# Altium schematic sheet USB_HOST HUB & USB_OTG.SchDoc
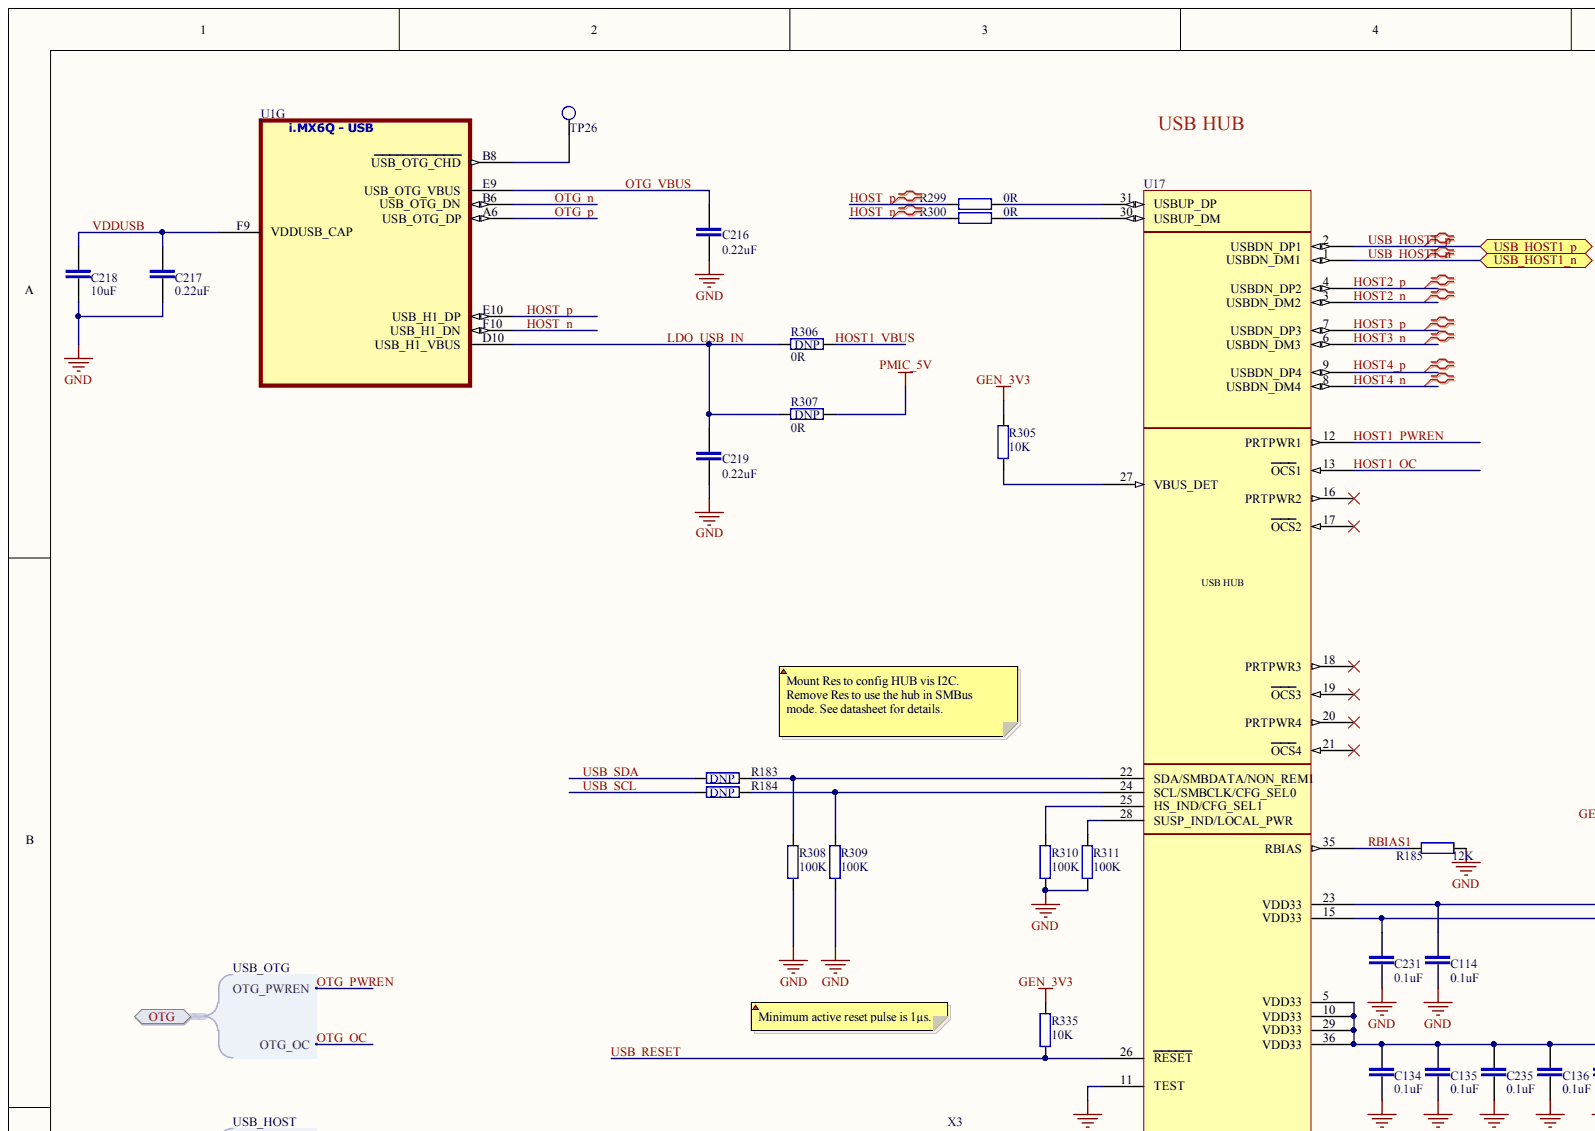
[diagram p0: USB_HOST HUB & USB_OTG.SchDoc, top left]
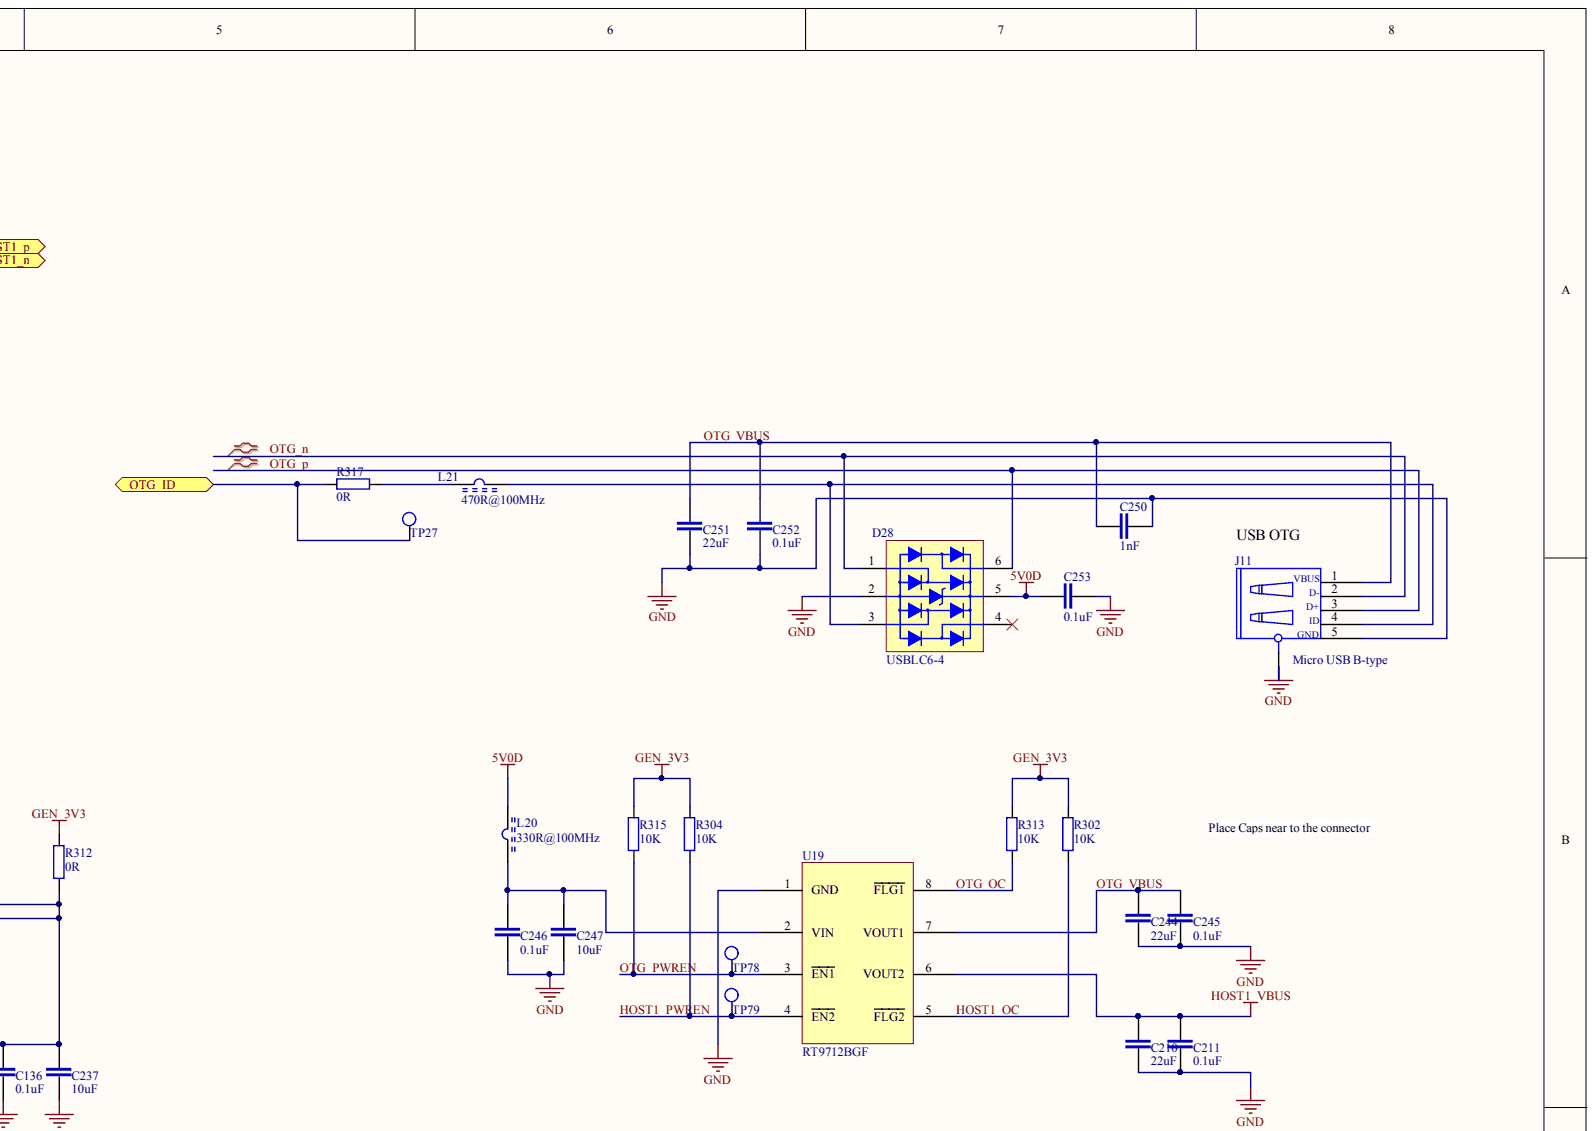
[diagram p1: USB_HOST HUB & USB_OTG.SchDoc, top right]
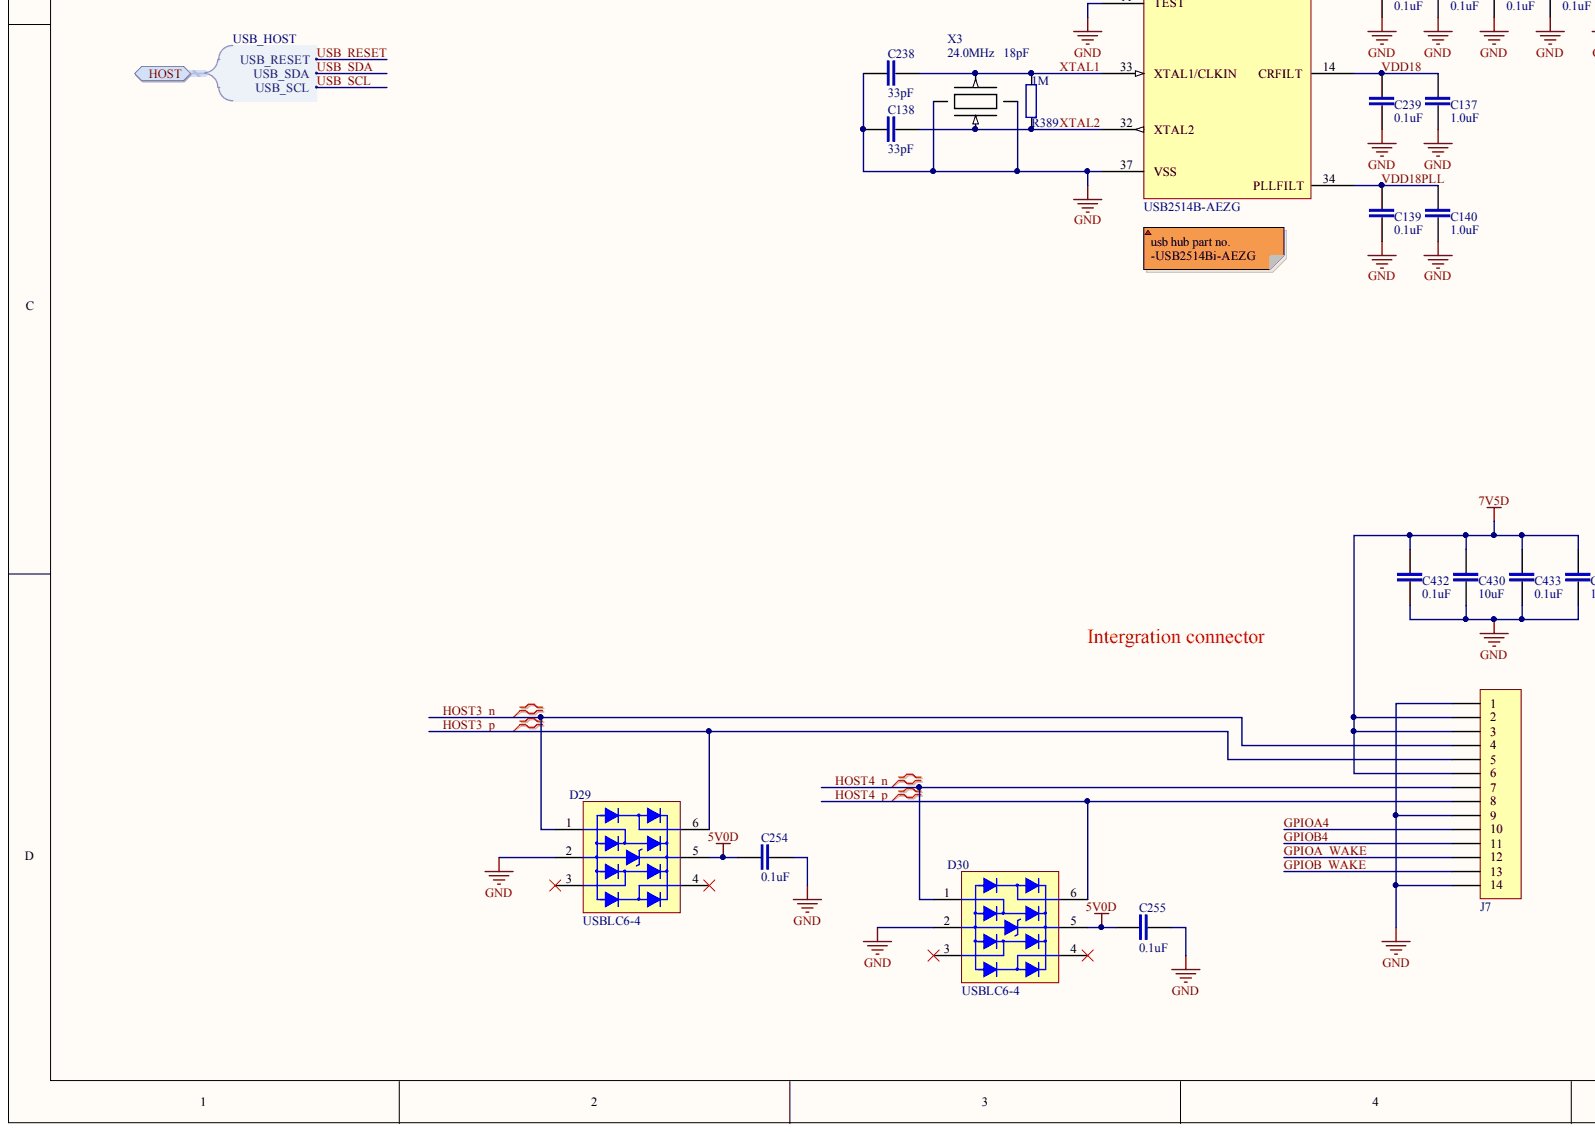
[diagram p2: USB_HOST HUB & USB_OTG.SchDoc, bottom left]
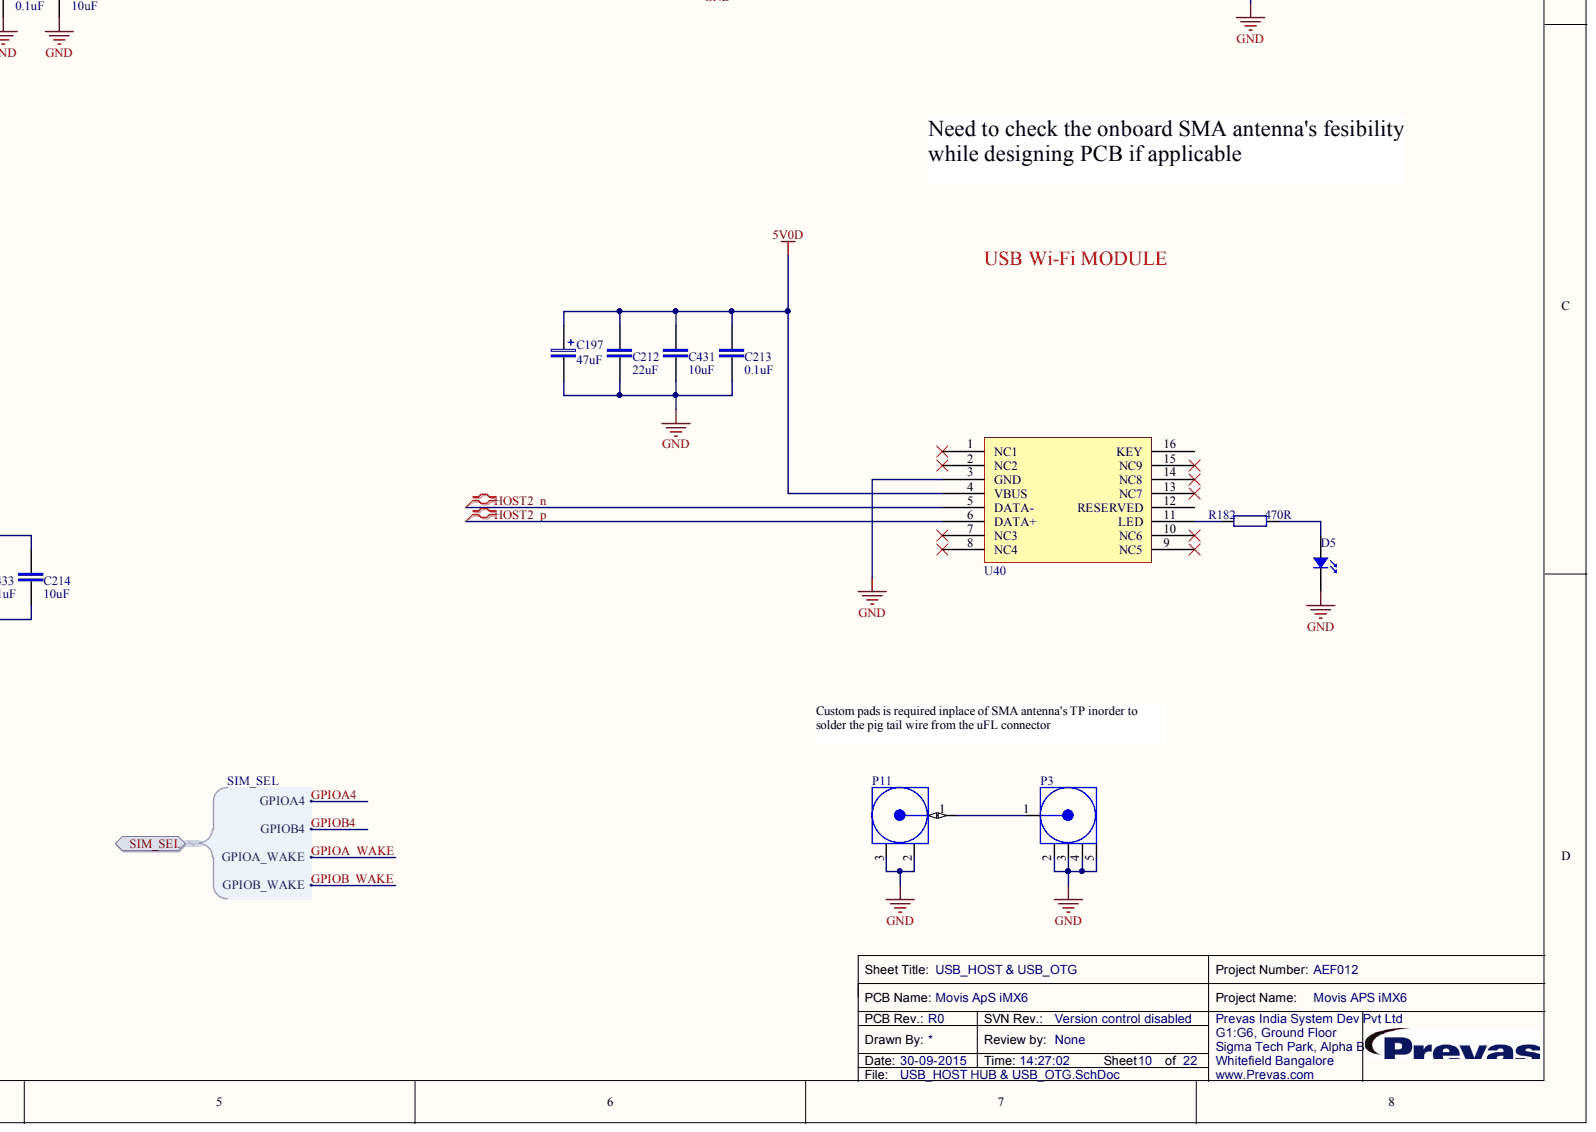
[diagram p3: USB_HOST HUB & USB_OTG.SchDoc, bottom right]

## Parts
C114  0.1uF  [CAPC1005X55N]
C134  0.1uF  [CAPC1005X55N]
C135  0.1uF  [CAPC1005X55N]
C136  0.1uF  [CAPC1005X55N]
C137  1.0uF  [CAPC1608X90N]
C138  33pF  [CAPC1005X55N]
C139  0.1uF  [CAPC1005X55N]
C140  1.0uF  [CAPC1608X90N]
C197  47uF  [CAPMP6032X26N]
C210  22uF  [CAPC3216X180N]
C211  0.1uF  [CAPC1005X55N]
C212  22uF  [CAPC3216X180N]
C213  0.1uF  [CAPC1005X55N]
C214  10uF  [CAPC1608X95N]
C216  0.22uF  [CAPC1005X55N]
C217  0.22uF  [CAPC1005X55N]
C218  10uF  [CAPC1608X95N]
C219  0.22uF  [CAPC1005X55N]
C231  0.1uF  [CAPC1005X55N]
C235  0.1uF  [CAPC1005X55N]
C237  10uF  [CAPC1608X95N]
C238  33pF  [CAPC1005X55N]
C239  0.1uF  [CAPC1005X55N]
C244  22uF  [CAPC3216X180N]
C245  0.1uF  [CAPC1005X55N]
C246  0.1uF  [CAPC1005X55N]
C247  10uF  [CAPC1608X95N]
C250  1nF  [CAPC1005X55N]
C251  22uF  [CAPC3216X180N]
C252  0.1uF  [CAPC1005X55N]
C253  0.1uF  [CAPC1005X55N]
C254  0.1uF  [CAPC1005X55N]
C255  0.1uF  [CAPC1005X55N]
C430  10uF  [CAPC1608X95N]
C431  10uF  [CAPC1608X95N]
C432  0.1uF  [CAPC1005X55N]
C433  0.1uF  [CAPC1005X55N]
D5  APG1608SEKC/T  [Kingbright_APG1608SEKC-T]  (value not drawn)
D28  USBLC6-4  [SOT23-6L]
D29  USBLC6-4  [SOT23-6L]
D30  USBLC6-4  [SOT23-6L]
J7  0533981471  [53398-1471]  (value not drawn)
J11  Micro USB B-type  [FCI_10103594-0001LF]
L20  330R@100MHz  [INDC1608X95N]
L21  470R@100MHz  [INDC4516X180N]
P3  638817-9  [CON_FAKRA_JACK_638817]  (value not drawn)
P11  COAX_2GND  [U.FL-R-SMT-1]  (value not drawn)
R182  470R  [RESC1608X55N]
R183  Fitted: DNP  [RESC1608X55N]  (hidden comment: NM)
R184  Fitted: DNP  [RESC1608X55N]  (hidden comment: NM)
R185  12K  [RESC1608X55N]
R299  0R  [RESC1608X55N]
R300  0R  [RESC1608X55N]
R302  10K  [RESC1608X55N]
R304  10K  [RESC1608X55N]
R305  10K  [RESC1608X55N]
R306  0R  Fitted: DNP  [RESC1608X55N]
R307  0R  Fitted: DNP  [RESC1608X55N]
R308  100K  [RESC1608X55N]
R309  100K  [RESC1608X55N]
R310  100K  [RESC1608X55N]
R311  100K  [RESC1608X55N]
R312  0R  [RESC1608X55N]
R313  10K  [RESC1608X55N]
R315  10K  [RESC1608X55N]
R317  0R  [RESC1608X55N]
R335  10K  [RESC1608X55N]
R389  1M  [RESC1608X55N]
TP26  Testpoint  [TP_SMD]  (value not drawn)  (no BOM)
TP27  Testpoint  [TP_SMD]  (value not drawn)  (no BOM)
TP78  Testpoint  [TP_SMD]  (value not drawn)  (no BOM)
TP79  Testpoint  [TP_SMD]  (value not drawn)  (no BOM)
U1  MCIMX6Q6AVT10AC  [BGA624C80P25X25_2100X2100X160]  (value not drawn)  (part G)
U17  USB2514B-AEZG  [PQFN50P600X600X100-37N]
U19  RT9712BGF  [SOP65P490X110-8N]
U40  WiFiHU-c  [WiFiHU-a-c]  (value not drawn)
X3  24.0MHz  Cload: 18pF  [XTAL700X500X110]

## Ports
HOST, HOST.USB_RESET, HOST.USB_SCL, HOST.USB_SDA, OTG, OTG.OTG_OC, OTG.OTG_PWREN, OTG_ID, SIM_SEL, SIM_SEL.GPIOA4, SIM_SEL.GPIOA_WAKE, SIM_SEL.GPIOB4, SIM_SEL.GPIOB_WAKE, USB_HOST1_N, USB_HOST1_P

## Nets
5V0D: C197.1 C212.2 C213.2 C253.2 C254.2 C255.2 C431.1 D28.5 D29.5 D30.5 L20.2 U40.4(VBUS)
7V5D: C214.1 C430.1 C432.1 C433.1 J7.2 J7.3 J7.6
GEN_3V3: R302.1 R304.1 R305.1 R312.2 R313.1 R315.1 R335.1
GND: C114.1 C134.1 C135.1 C136.1 C137.1 C138.1 C139.1 C140.1 C197.2 C210.1 C211.1 C212.1 C213.1 C214.2 C216.1 C217.1 C218.1 C219.1 C231.1 C235.1 C237.1 C238.1 C239.1 C244.1 C245.1 C246.1 C247.1 C250.2 C251.1 C252.1 C253.1 C254.1 C255.1 C430.2 C431.2 C432.2 C433.2 D5.C D28.2 D29.2 D30.2 J7.1 J7.9 J7.14 J11.5 J11.6 P3.2 P3.3 P3.4 P3.5 P11.2 P11.3 R185.2 R308.1 R309.1 R310.1 R311.1 U17.11(TEST) U17.37(VSS) U19.1(GND) U40.3(GND) X3.2 X3.4
PMIC_5V: R307.1
GPIOA4: J7.10 ; port SIM_SEL
GPIOA_WAKE: J7.12 ; port SIM_SEL
GPIOB4: J7.11 ; port SIM_SEL
GPIOB_WAKE: J7.13 ; port SIM_SEL
HOST1_OC: R302.2 U17.13(OCS1) U19.5(FLG2)
HOST1_PWREN: R304.2 TP79.1 U17.12(PRTPWR1) U19.4(EN2)
HOST1_VBUS: C210.2 C211.2 R306.1 U19.6(VOUT2)
HOST2_N: U17.3(USBDN_DM2) U40.5(DATA-)
HOST2_P: U17.4(USBDN_DP2) U40.6(DATA+)
HOST3_N: D29.1 J7.4 U17.6(USBDN_DM3)
HOST3_P: D29.6 J7.5 U17.7(USBDN_DP3)
HOST4_N: D30.1 J7.7 U17.8(USBDN_DM4)
HOST4_P: D30.6 J7.8 U17.9(USBDN_DP4)
HOST_N: R300.1 U1.F10(USB_H1_DN)
HOST_P: R299.1 U1.E10(USB_H1_DP)
LDO_USB_IN: C219.2 R306.2 R307.2 U1.D10(USB_H1_VBUS)
OTG_ID: R317.2 TP27.1 ; port OTG_ID
OTG_N: D28.1 J11.2(D-) U1.B6(USB_OTG_DN)
OTG_OC: R313.2 U19.8(FLG1) ; port OTG
OTG_P: D28.6 J11.3(D+) U1.A6(USB_OTG_DP)
OTG_PWREN: R315.2 TP78.1 U19.3(EN1) ; port OTG
OTG_VBUS: C216.2 C244.2 C245.2 C250.1 C251.2 C252.2 J11.1(VBUS) U1.E9(USB_OTG_VBUS) U19.7(VOUT1)
RBIAS1: R185.1 U17.35(RBIAS)
USB_HOST1_N: U17.1(USBDN_DM1) ; port USB_HOST1_N
USB_HOST1_P: U17.2(USBDN_DP1) ; port USB_HOST1_P
USB_RESET: R335.2 U17.26(RESET) ; port HOST
USB_SCL: R184.1 ; port HOST
USB_SDA: R183.1 ; port HOST
VDD18: C137.2 C239.2 U17.14(CRFILT)
VDD18PLL: C139.2 C140.2 U17.34(PLLFILT)
VDDUSB: C217.2 C218.2 U1.F9(VDDUSB_CAP)
XTAL1: C238.2 R389.2 U17.33(XTAL1/CLKIN) X3.3
XTAL2: C138.2 R389.1 U17.32(XTAL2) X3.1
NetC114_2: C114.2 C134.2 C135.2 C136.2 C231.2 C235.2 C237.2 R312.1 U17.5(VDD33) U17.10(VDD33) U17.15(VDD33) U17.23(VDD33) U17.29(VDD33) U17.36(VDD33)
NetC246_2: C246.2 C247.2 L20.1 U19.2(VIN)
NetD5_A: D5.A R182.2
NetD28_3: D28.3 J11.4(ID) L21.2
NetL21_1: L21.1 R317.1
NetP3_1: P3.1 P11.1
NetR182_1: R182.1 U40.11(LED)
NetR183_2: R183.2 R308.2 U17.22(SDA/SMBDATA/NON_REM1)
NetR184_2: R184.2 R309.2 U17.24(SCL/SMBCLK/CFG_SEL0)
NetR299_2: R299.2 U17.31(USBUP_DP)
NetR300_2: R300.2 U17.30(USBUP_DM)
NetR305_2: R305.2 U17.27(VBUS_DET)
NetR310_2: R310.2 U17.25(HS_IND/CFG_SEL1)
NetR311_2: R311.2 U17.28(SUSP_IND/LOCAL_PWR)
NetTP26_1: TP26.1 U1.B8(USB_OTG_CHD)

Not connected: D28 4; D29 3 4; D30 3 4; U17 16(PRTPWR2) 17(OCS2) 18(PRTPWR3) 19(OCS3) 20(PRTPWR4) 21(OCS4); U40 1(NC1) 2(NC2) 7(NC3) 8(NC4) 9(NC5) 10(NC6) 12(RESERVED) 13(NC7) 14(NC8) 15(NC9) 16(KEY)

## Library data of the parts
C114 C134-C136 C139 C211 C213 C231 C235 C239 C245 C246 C252-C255 C432 C433 = INNISI-73: CAP CER 0.1UF 50V 10% X5R 0402; ref_part_type: /capacitor/non_polarized/ceramic/; source_manufacturer_1: TDK Corporation; source_manufacturer_1_pn: C1005X5R1H104K050BB; cae_altium_pcb_status: Prototype; Database Table Name: altium_default; db_rev: 7569; elec_temp_range: -55 to +85 C; phy_height: 0.55mm; phy_mounting: SMD; phy_packaging_type: 0402; ref_datasheet: INNISI-71; cae_altium_sch_status: Prototype; Component Kind: Standard; ComponentLink1Description: Datasheet; ComponentLink1URL: https://cop201.prevas.dk/viewvc/datasheet/INNISI-71.pdf?root=hw_components&view=co; db_created: 2015-08-03 03:34:59.0; db_last_updated: 03-08-2015 03:35:01; db_local_id: INNISI-73; db_status: Prototype; elec_tolerance: ±10%; elec_value: 0.1uF; elec_voltage_rating: 50V; phy_material: X5R; ref_keyword: CAP CER 0.1UF 50V 10% X5R 0402; Library Name: altium_mysql.dblib
  C114 C134-C136 C139 C211 C213 C231 C235 C239 C245 C252-C255: Mounted: Yes
C137 C140 = INNISI-79: CAP CER 1UF 16V 10% X7R 0603; ref_part_type: /capacitor/non_polarized/ceramic/; source_manufacturer_1: Murata; source_manufacturer_1_pn: GRM188R71C105KE15D; cae_altium_pcb_status: Prototype; Database Table Name: altium_default; db_rev: 7576; elec_temp_range: -55 to +125; phy_height: 0.90mm; phy_mounting: SMD; phy_packaging_type: 0603 (1608 Metric); ref_datasheet: INNISI-33; ref_keyword: Ceramic Capacitor 0603; cae_altium_sch_status: Prototype; Component Kind: Standard; ComponentLink1Description: Datasheet; ComponentLink1URL: https://cop201.prevas.dk/viewvc/datasheet/INNISI-33.pdf?root=hw_components&view=co; db_created: 2015-08-03 05:39:06.0; db_last_updated: 03-08-2015 05:39:08; db_local_id: INNISI-79; db_status: Prototype; elec_tolerance: 10%; elec_value: 1.0uF; elec_voltage_rating: 16V; Mounted: Yes; phy_material: X7R; Library Name: altium_mysql.dblib
C138 C238 = innisi-80: CAP CER 33PF 50V 5% NPO 0402; ref_part_type: /capacitor/non_polarized/ceramic/; source_manufacturer_1: Yageo; source_manufacturer_1_pn: CC0402JRNPO9BN330; cae_altium_pcb_status: Prototype; Database Table Name: altium_default; db_rev: 7577; elec_temp_range: -55 to +125C; phy_height: 0.55mm; phy_material: C0G,NPO; phy_mounting: SMD; phy_packaging_type: 0402; ref_datasheet: INNISI-72; ref_keyword: Multilayer chip capacitor; cae_altium_sch_status: Prototype; Component Kind: Standard; ComponentLink1Description: Datasheet; ComponentLink1URL: https://cop201.prevas.dk/viewvc/datasheet/INNISI-72.pdf?root=hw_components&view=co; db_created: 2015-08-03 05:45:15.0; db_last_updated: 03-08-2015 05:45:17; db_local_id: INNISI-80; db_status: Prototype; elec_tolerance: 5%; elec_value: 33pF; elec_voltage_rating: 50V; Mounted: Yes; Library Name: altium_mysql.dblib
C197 = INVIHA-51: CAP TANT 47UF 10V 10% 2312; ref_part_type: /capacitor/polarized/ta/; source_manufacturer_1: AVX Corporation; source_manufacturer_1_pn: TAJC476K010RNJ; cae_altium_pcb_status: Prototype; Database Table Name: altium_default; db_rev: 7666; elec_temp_range: -55 to 125°C; phy_height: 2.8mm; phy_material: Tantalum; phy_mounting: SMD; phy_packaging_type: 2312; ref_datasheet: INVIHA-12; ref_keyword: CAPACITOR TANT; cae_altium_sch_status: Prototype; Component Kind: Standard; ComponentLink1Description: Datasheet; ComponentLink1URL: https://cop201.prevas.dk/viewvc/datasheet/INVIHA-12.pdf?root=hw_components&view=co; db_created: 2015-08-05 05:24:04.0; db_last_updated: 05-08-2015 05:24:06; db_local_id: INVIHA-51; db_status: Prototype; elec_tolerance: 10%; elec_value: 47uF; elec_voltage_rating: 10V; Library Name: altium_mysql.dblib
C210 C212 C244 C251 = INNISI-77: CAP CER 22UF 25V 10% X5R 1206; ref_part_type: /capacitor/non_polarized/ceramic/; source_manufacturer_1: Murata Electronics; source_manufacturer_1_pn: GRM31CR61E226KE15L; cae_altium_pcb_status: Prototype; Database Table Name: altium_default; db_rev: 7573; elec_temp_range: -55 to +85 C; phy_height: 1.8mm; phy_mounting: SMD; phy_packaging_type: 1206; ref_datasheet: INNISI-74; ref_keyword: Multilayer chip capacitor; cae_altium_sch_status: Prototype; Component Kind: Standard; ComponentLink1Description: Datasheet; ComponentLink1URL: https://cop201.prevas.dk/viewvc/datasheet/INNISI-74.pdf?root=hw_components&view=co; db_created: 2015-08-03 04:56:21.0; db_last_updated: 03-08-2015 04:56:22; db_local_id: INNISI-77; db_status: Prototype; elec_tolerance: 10%; elec_value: 22uF; elec_voltage_rating: 25V; phy_material: X5R; Library Name: altium_mysql.dblib
C214 C237 C430 C431 = INNISI-78: CAP CER 10UF 16V 10% X5R 0603; ref_part_type: /capacitor/non_polarized/ceramic/; source_manufacturer_1: Murata; source_manufacturer_1_pn: GRM188R61C106KAALD; cae_altium_pcb_status: Prototype; Database Table Name: altium_default; db_rev: 7574; elec_temp_range: -55 to +85C; phy_height: 0.95mm; phy_mounting: SMD; phy_packaging_type: 0603; ref_datasheet: INNISI-75; ref_keyword: Ceramic capacitor X5R 0603; cae_altium_sch_status: Prototype; Component Kind: Standard; ComponentLink1Description: Datasheet; ComponentLink1URL: https://cop201.prevas.dk/viewvc/datasheet/INNISI-75.pdf?root=hw_components&view=co; db_created: 2015-08-03 05:08:42.0; db_last_updated: 03-08-2015 05:08:44; db_local_id: INNISI-78; db_status: Prototype; elec_tolerance: 10%; elec_value: 10uF; elec_voltage_rating: 16V; phy_material: X5R; Library Name: altium_mysql.dblib
  C237: Mounted: Yes
C216 C217 C219 = innisi-76: CAP CER 0.22UF 16V 10% X7R 0402; ref_part_type: /capacitor/non_polarized/ceramic/; source_manufacturer_1: Murata; source_manufacturer_1_pn: GRM155R71C224KA12D; cae_altium_pcb_status: Prototype; Database Table Name: altium_default; db_rev: 7572; elec_temp_range: -55 to +125C; phy_height: 0.55mm; phy_mounting: SMD; phy_packaging_type: 0402; ref_datasheet: INNISI-73; ref_keyword: Ceramic capacitor X7R 0402; cae_altium_sch_status: Prototype; Component Kind: Standard; ComponentLink1Description: Datasheet; ComponentLink1URL: https://cop201.prevas.dk/viewvc/datasheet/INNISI-73.pdf?root=hw_components&view=co; db_created: 2015-08-03 04:28:56.0; db_last_updated: 03-08-2015 04:28:58; db_local_id: INNISI-76; db_status: Prototype; elec_tolerance: 10%; elec_value: 0.22uF; elec_voltage_rating: 16V; phy_material: X7R; Library Name: altium_mysql.dblib
C218 C247 = innisi-78: CAP CER 10UF 16V 10% X5R 0603; ref_part_type: /capacitor/non_polarized/ceramic/; source_manufacturer_1: Murata; source_manufacturer_1_pn: GRM188R61C106KAALD; cae_altium_pcb_status: Prototype; Database Table Name: altium_default; db_rev: 7574; elec_temp_range: -55 to +85C; phy_height: 0.95mm; phy_mounting: SMD; phy_packaging_type: 0603; ref_datasheet: INNISI-75; ref_keyword: Ceramic capacitor X5R 0603; cae_altium_sch_status: Prototype; Component Kind: Standard; ComponentLink1Description: Datasheet; ComponentLink1URL: https://cop201.prevas.dk/viewvc/datasheet/INNISI-75.pdf?root=hw_components&view=co; db_created: 2015-08-03 05:08:42.0; db_last_updated: 03-08-2015 05:08:44; db_local_id: INNISI-78; db_status: Prototype; elec_tolerance: 10%; elec_value: 10uF; elec_voltage_rating: 16V; phy_material: X5R; Library Name: altium_mysql.dblib
C250 = INNISI-84: CAP CER 1nF 50V 10% X7R 0402; ref_part_type: /capacitor/non_polarized/ceramic/; source_manufacturer_1: Murata; source_manufacturer_1_pn: GRM155R71H102KA01J; cae_altium_pcb_status: Prototype; Database Table Name: altium_default; db_rev: 7585; elec_temp_range: -55 to +125C; phy_height: 0.55mm; phy_mounting: SMD; phy_packaging_type: 0402; ref_datasheet: INNISI-73; ref_keyword: Ceramic capacitor X7R 0402; cae_altium_sch_status: Prototype; Component Kind: Standard; ComponentLink1Description: Datasheet; ComponentLink1URL: https://cop201.prevas.dk/viewvc/datasheet/INNISI-73.pdf?root=hw_components&view=co; db_created: 2015-08-03 06:26:19.0; db_last_updated: 03-08-2015 06:26:21; db_local_id: INNISI-84; db_status: Prototype; elec_tolerance: 10%; elec_value: 1nF; elec_voltage_rating: 50V; Mounted: Yes; phy_material: X7R; Library Name: altium_mysql.dblib
D5 = GEPW-159: SMD LED, 610nm, 300mcd; ref_part_type: /optical/emmision/diode/; source_manufacturer_1: Kingbright; cae_altium_pcb_status: Prototype; Database Table Name: altium_default; db_rev: 3356; elec_current_rating: IF=20mA; elec_temp_range: -40 to 85; elec_voltage_rating: 2.05V; phy_height: 0.25; phy_packaging_type: 0603; ref_datasheet: GEPW-137; ref_keyword: Super Bright Orange; cae_altium_sch_status: Prototype; Component Kind: Standard; ComponentLink1Description: Datasheet; ComponentLink1URL: https://cop201.prevas.dk/viewvc/datasheet/GEPW-137.pdf?root=hw_components&view=co; db_created: 2011-04-26 11:07:13.0; db_last_updated: 26-04-2011 11:07:13; db_local_id: GEPW-159; db_status: Prototype; elec_frequency_rating: 610nm; elec_value: APG1608SEKC/T; phy_mounting: SMD; source_manufacturer_1_pn: APG1608SEKC/T; Library Name: altium_mysql.dblib
D28-D30 = NIMA-155: Dual very low capacitance ESD protection for USB 2.0 up to 480Mb/s; ref_part_type: /diode/transient/; source_manufacturer_1: STMicroelectronics; cae_altium_pcb_status: Prototype; Database Table Name: altium_default; db_rev: 1817; elec_temp_range: -40 to 125; elec_voltage_rating: 5V; phy_height: 1.45mm; phy_mounting: SMD; ref_datasheet: NIMA-115; cae_altium_sch_status: Prototype; Component Kind: Standard; ComponentLink1Description: Datasheet; ComponentLink1URL: https://cop201.prevas.dk/viewvc/datasheet/NIMA-115.pdf?root=hw_components&view=co; db_created: 2009-09-25 21:20:59; db_last_updated: 25-09-2009 21:21:20; db_local_id: NIMA-155; db_status: Prototype; elec_value: USBLC6-4; Mounted: Yes; phy_packaging_type: SOT23-6L; ref_keyword: ESD; source_manufacturer_1_pn: USBLC6-4; Library Name: altium_mysql.dblib
J7 = INNISI-67: CONN HEADER 14POS 1.25MM VRT SMD; ref_part_type: /connector/14 pins/; source_manufacturer_1: Molex Inc; cae_altium_pcb_status: Prototype; Database Table Name: altium_default; db_rev: 7500; elec_current_rating: 1 A; ref_datasheet: INNISI-66; ref_keyword: PicoBlade Header; cae_altium_sch_status: Prototype; Component Kind: Standard; ComponentLink1Description: Datasheet; ComponentLink1URL: https://cop201.prevas.dk/viewvc/datasheet/INNISI-66.pdf?root=hw_components&view=co; db_created: 2015-07-06 03:02:08.0; db_last_updated: 06-07-2015 03:02:10; db_local_id: INNISI-67; db_status: Prototype; elec_value: 0533981471; phy_mounting: SMD; source_manufacturer_1_pn: 0533981471; Library Name: altium_mysql.dblib
J11 = CHPE-234: MICRO USB B, RECEPTACLE, 5POS, SURFACE MOUNT RIGHT ANGLE; ref_part_type: /connector/; source_manufacturer_1: FCI; source_manufacturer_1_pn: 10103594-0001LF; cae_altium_pcb_status: Prototype; Database Table Name: altium_default; db_rev: 4334; phy_height: 2.99; phy_mounting: SMD; ref_datasheet: GEPW-195; ref_keyword: USB Micro Bconnector; cae_altium_sch_status: Prototype; Component Kind: Standard; ComponentLink1Description: Datasheet; ComponentLink1URL: https://cop201.prevas.dk/viewvc/datasheet/GEPW-195.pdf?root=hw_components&view=co; db_created: 2012-02-22 13:05:39.0; db_last_updated: 22-02-2012 14:46:37; db_local_id: CHPE-234; db_status: Prototype; elec_value: Micro USB B-type; Library Name: altium_mysql.dblib
L20 = TELA-164: Chip EMIFIL Inductor Type, 330R@100MHz, 0.15R@0Hz; ref_part_type: /inductor/lossy/; source_manufacturer_1: Murata; source_manufacturer_1_pn: BLM18PG331SN1*; cae_altium_pcb_status: Prototype; Database Table Name: altium_default; db_rev: 5543; elec_current_rating: 1.2A; elec_frequency_rating: 100MHz; elec_temp_range: -55 to 125; elec_tolerance: 25%; phy_height: 0.95mm; phy_material: Ferrite; phy_mounting: SMD; phy_packaging_type: 0603; ref_datasheet: SOMO-49; ref_keyword: Ferrite bead 0603; cae_altium_sch_status: Prototype; Component Kind: Standard; ComponentLink1Description: Datasheet; ComponentLink1URL: https://cop201.prevas.dk/viewvc/datasheet/SOMO-49.pdf?root=hw_components&view=co; db_created: 2013-03-14 15:35:31.0; db_last_updated: 14-03-2013 16:11:58; db_local_id: TELA-164; db_status: Prototype; elec_value: 330R@100MHz; Library Name: altium_mysql.dblib
L21 = NIMA-36: Chip EMIFIL Inductor Type, 470R@100MHz, 0.05R@0Hz; ref_part_type: /inductor/lossy/; source_manufacturer_1: Murata; source_manufacturer_1_pn: BLM41PG471SN1L; cae_altium_pcb_status: Prototype; Database Table Name: altium_default; db_rev: 5393; elec_current_rating: 2.0A; elec_frequency_rating: 100MHz; elec_temp_range: -55 to 125; elec_tolerance: 25%; phy_height: 1.8mm; phy_material: Ferrite; phy_mounting: SMD; phy_packaging_type: 1806; ref_datasheet: NIMA-29; ref_keyword: Ferrite bead 1806; cae_altium_sch_status: Prototype; Component Kind: Standard; ComponentLink1Description: Datasheet; ComponentLink1URL: https://cop201.prevas.dk/viewvc/datasheet/NIMA-29.pdf?root=hw_components&view=co; db_created: 2009-03-20 21:04:27; db_last_updated: 05-12-2012 15:13:29; db_local_id: NIMA-36; db_status: Prototype; elec_value: 470R@100MHz; Library Name: altium_mysql.dblib
P3 = INNISI-61: D/C R/A PCB FAKRA SMB ASY,KEYI; ref_part_type: /connector/; source_manufacturer_1: TE Connectivity; cae_altium_pcb_status: Prototype; Database Table Name: altium_default; db_rev: 7952; elec_current_rating: 1A; elec_frequency_rating: 0 – 3000MHz; elec_temp_range: -40°C to + 85?°C; phy_height: 10.75?mm; phy_mounting: Leaded; ref_datasheet: INNISI-59; ref_keyword: RF Connectors; cae_altium_sch_status: Prototype; Component Kind: Standard; ComponentLink1Description: Datasheet; ComponentLink1URL: https://cop201.prevas.dk/viewvc/datasheet/INNISI-59.pdf?root=hw_components&view=co; db_created: 2015-06-17 07:10:25.0; db_last_updated: 25-09-2015 05:38:56; db_local_id: INNISI-61; db_status: Prototype; elec_value: 638817-9; source_manufacturer_1_pn: 638817-9; Library Name: altium_mysql.dblib
P11 = INNISI-66: Ultra Small Surface Mount Coaxial Connectors; ref_part_type: /connector/; source_manufacturer_1: Hirose Electric; source_manufacturer_1_pn: U.FL-R-SMT-1(01); cae_altium_pcb_status: Prototype; Database Table Name: altium_default; db_rev: 7499; elec_current_rating: 10mA; elec_temp_range: -40C - +90C; phy_mounting: SMD; ref_datasheet: INNISI-65; ref_keyword: U.FL connectors; cae_altium_sch_status: Prototype; Component Kind: Standard; ComponentLink1Description: Datasheet; ComponentLink1URL: https://cop201.prevas.dk/viewvc/datasheet/INNISI-65.pdf?root=hw_components&view=co; db_created: 2015-07-06 02:54:10.0; db_last_updated: 06-07-2015 02:54:11; db_local_id: INNISI-66; db_status: Prototype; Library Name: altium_mysql.dblib
R182 = INVIHA-7: RES SMD 470 OHM 1% 1/10W 0603; ref_part_type: /resistor/fixed/; source_manufacturer_1: Yageo; source_manufacturer_1_pn: RC0603FR-07470RL; cae_altium_pcb_status: Prototype; Database Table Name: altium_default; db_rev: 7584; elec_power_rating: 0.1W; elec_temp_range: -55 to +155° C; phy_height: 0.55mm; phy_packaging_type: 0603; ref_datasheet: INVIHA-2; ref_keyword: Chip Resistor; cae_altium_sch_status: Prototype; Component Kind: Standard; ComponentLink1Description: Datasheet; ComponentLink1URL: https://cop201.prevas.dk/viewvc/datasheet/INVIHA-2.pdf?root=hw_components&view=co; db_created: 2015-08-03 06:24:05.0; db_last_updated: 03-08-2015 6:24:06 AM; db_local_id: INVIHA-7; db_status: Prototype; elec_tolerance: 1%; elec_value: 470R; phy_mounting: SMD; Library Name: altium_mysql.dblib
R183 R184 = CHPE-128: Resistor 0603 Not Mounted; ref_part_type: /resistor/fixed/; cae_altium_pcb_status: Prototype; Database Table Name: altium_default; db_rev: 3505; phy_height: 0.55mm; phy_material: Thick Film; phy_mounting: SMD; phy_packaging_type: 0603; ref_keyword: Thick Film Chip Resistor; cae_altium_sch_status: Prototype; Component Kind: Standard; ComponentLink1Description: Datasheet; db_created: 2011-05-25 12:57:29.0; db_last_updated: 25-05-2011 12:57:29; db_local_id: CHPE-128; db_status: Prototype; elec_value: NM; Library Name: altium_mysql.dblib
R185 = INVIHA-17: RES SMD 12K OHM 1% 1/10W 0603; ref_part_type: /resistor/fixed/; source_manufacturer_1: Yageo; source_manufacturer_1_pn: RC0603FR-0712KL; cae_altium_pcb_status: Prototype; Database Table Name: altium_default; db_rev: 7599; elec_power_rating: 0.1W; elec_temp_range: -55 to +155° C; phy_height: 0.55mm; phy_packaging_type: 0603; ref_datasheet: INVIHA-2; ref_keyword: Chip Resistor; cae_altium_sch_status: Prototype; Component Kind: Standard; ComponentLink1Description: Datasheet; ComponentLink1URL: https://cop201.prevas.dk/viewvc/datasheet/INVIHA-2.pdf?root=hw_components&view=co; db_created: 2015-08-03 07:05:19.0; db_last_updated: 03-08-2015 7:05:21 AM; db_local_id: INVIHA-17; db_status: Prototype; elec_tolerance: 1%; elec_value: 12K; phy_mounting: SMD; Library Name: altium_mysql.dblib
R299 R300 R306 R307 R312 R317 = INVIHA-4: RES SMD 0.0 OHM JUMPER 1/10W; ref_part_type: /resistor/fixed/; source_manufacturer_1: Panasonic Electronic Components; source_manufacturer_1_pn: ERJ-3GEY0R00V; cae_altium_pcb_status: Prototype; Database Table Name: altium_default; db_rev: 7580; elec_power_rating: 0.1W; elec_temp_range: -55 to +155° C; phy_height: 0.55mm; phy_packaging_type: 0603; ref_datasheet: INVIHA-3; ref_keyword: Chip Resistor; cae_altium_sch_status: Prototype; Component Kind: Standard; ComponentLink1Description: Datasheet; ComponentLink1URL: https://cop201.prevas.dk/viewvc/datasheet/INVIHA-3.pdf?root=hw_components&view=co; db_created: 2015-08-03 05:59:19.0; db_last_updated: 03-08-2015 5:59:21 AM; db_local_id: INVIHA-4; db_status: Prototype; elec_value: 0R; phy_mounting: SMD; Library Name: altium_mysql.dblib
R302 R304 R305 R313 R315 R335 = INVIHA-3: RES SMD 10K OHM 1% 1/10W 0603; ref_part_type: /resistor/fixed/; source_manufacturer_1: Yageo; source_manufacturer_1_pn: RC0603FR-0710KL; cae_altium_pcb_status: Prototype; Database Table Name: altium_default; db_rev: 7575; elec_power_rating: 0.1W; elec_temp_range: -55 to +155° C; phy_height: 0.55mm; phy_packaging_type: 0603; ref_datasheet: INVIHA-2; ref_keyword: Chip Resistor; cae_altium_sch_status: Prototype; Component Kind: Standard; ComponentLink1Description: Datasheet; ComponentLink1URL: https://cop201.prevas.dk/viewvc/datasheet/INVIHA-2.pdf?root=hw_components&view=co; db_created: 2015-08-03 05:39:03.0; db_last_updated: 03-08-2015 5:39:05 AM; db_local_id: INVIHA-3; db_status: Prototype; elec_tolerance: 1%; elec_value: 10K; Mounted: Yes; phy_mounting: SMD; Library Name: altium_mysql.dblib
R308-R311 = INVIHA-20: RES SMD 100K OHM 1% 1/10W 0603; ref_part_type: /resistor/fixed/; source_manufacturer_1: Yageo; source_manufacturer_1_pn: RC0603FR-07100KL; cae_altium_pcb_status: Prototype; Database Table Name: altium_default; db_rev: 7602; elec_power_rating: 0.1W; elec_temp_range: -55 to +155° C; phy_height: 0.55mm; phy_packaging_type: 0603; ref_keyword: Chip Resistor; cae_altium_sch_status: Prototype; Component Kind: Standard; ComponentLink1Description: Datasheet; ComponentLink1URL: https://cop201.prevas.dk/viewvc/datasheet/INVIHA-2.pdf?root=hw_components&view=co; db_created: 2015-08-03 07:16:43.0; db_last_updated: 03-08-2015 7:16:45 AM; db_local_id: INVIHA-20; db_status: Prototype; elec_tolerance: 1%; elec_value: 100K; phy_mounting: SMD; ref_datasheet: INVIHA-2; Library Name: altium_mysql.dblib
  R310 R311: Mounted: Yes
R389 = INVIHA-31: RES SMD 1M OHM 1% 1/10W 0603; ref_part_type: /resistor/fixed/; source_manufacturer_1: Yageo; source_manufacturer_1_pn: RC0603FR-071ML; cae_altium_pcb_status: Prototype; Database Table Name: altium_default; db_rev: 7622; elec_power_rating: 0.1W; elec_temp_range: -55 to +155° C; phy_height: 0.55mm; phy_packaging_type: 0603; ref_datasheet: INVIHA-2; ref_keyword: Chip Resistor; cae_altium_sch_status: Prototype; Component Kind: Standard; ComponentLink1Description: Datasheet; ComponentLink1URL: https://cop201.prevas.dk/viewvc/datasheet/INVIHA-2.pdf?root=hw_components&view=co; db_created: 2015-08-03 08:46:27.0; db_last_updated: 03-08-2015 8:46:29 AM; db_local_id: INVIHA-31; db_status: Prototype; elec_tolerance: 1%; elec_value: 1M; phy_mounting: SMD; Library Name: altium_mysql.dblib
TP26 TP27 TP78 TP79 = JEPE-114: Test Point SMD; ref_part_type: /pcb_item/; cae_altium_pcb_status: Prototype; Database Table Name: altium_default; db_rev: 3281; cae_altium_sch_status: Prototype; ComponentLink1Description: Datasheet; db_created: 2008-08-18 11:39:42; db_last_updated: 23-03-2011 14:14:06; db_local_id: JEPE-114; db_status: Prototype; elec_value: Test Point; phy_mounting: SMD; ref_keyword: Test  Point; Library Name: altium_mysql.dblib
U1 = INRAAN-5: i.MX 6Dual/6Quad; ref_part_type: /integrated/digital/processor/general/; AGILE_PN: 344-01218; CAD_APPROVED: Yes; cae_altium_pcb_status: Prototype; CLASS: IC; Database Table Name: altium_default; db_rev: 6247; elec_temp_range: -40 to +105; elec_voltage_rating: 1.5V; MFG_NAME01: FREESCALE SEMICONDUCTOR; phy_height: 1.85; phy_mounting: SMD; phy_packaging_type: FCPBGA96_624; ref_datasheet: INRAAN-6; cae_altium_sch_status: Prototype; Component Kind: Standard; ComponentLink1Description: Datasheet; ComponentLink1URL: https://cop201.prevas.dk/viewvc/datasheet/INRAAN-6.pdf?root=hw_components&view=co; db_created: 2013-10-21 12:25:57.0; db_last_updated: 27-12-2013 09:32:57; db_local_id: INRAAN-5; db_status: Prototype; elec_value: MCIMX6Q6AVT10AC; HEIGHT: 2.16MM; MFG_PN01: MCIMX6Q6AVT10AC; ref_keyword: i.MX 6Dual/6Quad; ROHS: Yes; source_manufacturer_1: Freescale Semiconductor; source_manufacturer_1_pn: MCIMX6Q6AVT10AC; Library Name: altium_mysql.dblib
U17 = CHPE-139: USB 2.0 High-Speed 4-Port Hub Controller; ref_part_type: /integrated/digital/interface/usb/; source_manufacturer_1: SMSC; cae_altium_pcb_status: Prototype; Database Table Name: altium_default; db_rev: 3604; elec_temp_range: 0 to 85; elec_voltage_rating: 3.3V; phy_height: 1.0mm; phy_mounting: SMD; phy_packaging_type: QFN-36; ref_datasheet: TELA-48; ref_keyword: USB Hub 4-Port; cae_altium_sch_status: Prototype; Component Kind: Standard; ComponentLink1Description: Datasheet; ComponentLink1URL: https://cop201.prevas.dk/viewvc/datasheet/TELA-48.pdf?root=hw_components&view=co; db_created: 2011-06-01 16:58:09.0; db_last_updated: 09-06-2011 20:14:12; db_local_id: CHPE-139; db_status: Prototype; elec_value: USB2514B-AEZG; source_manufacturer_1_pn: USB2514B-AEZG; Library Name: altium_mysql.dblib
U19 = INRAAN-224: 90m?, 1A/1.5A High-Side Dual Power Switches with Flag; ref_part_type: /elmech/transducer/switch/; source_manufacturer_1: RICHTEK; cae_altium_pcb_status: Prototype; Database Table Name: altium_default; db_rev: 6926; elec_current_rating: 2A; elec_temp_range: -40C to 85C; elec_voltage_rating: 5.5V; phy_height: 1.1mm; phy_mounting: SMD; phy_packaging_type: MSOP; ref_datasheet: INRAAN-209; cae_altium_sch_status: Prototype; Component Kind: Standard; ComponentLink1Description: Datasheet; ComponentLink1URL: https://cop201.prevas.dk/viewvc/datasheet/INRAAN-209.pdf?root=hw_components&view=co; db_created: 2014-12-01 06:30:55.0; db_last_updated: 01-12-2014 06:30:56; db_local_id: INRAAN-224; db_status: Prototype; elec_value: RT9712BGF; ref_keyword: High-Side Dual Power Switches with Flag; source_manufacturer_1_pn: RT9712BGF; Library Name: altium_mysql.dblib
U40 = INNISI-31: USB WiFi Module Supports 802.11b/g/n Standards; ref_part_type: /module/communication/; source_manufacturer_1: Radicom; cae_altium_pcb_status: Prototype; Database Table Name: altium_default; db_rev: 7376; elec_current_rating: 155mA; elec_temp_range: -40°C to +85°C; elec_voltage_rating: 5V; phy_mounting: Leaded; ref_keyword: USB WiFi Modem; cae_altium_sch_status: Prototype; Component Kind: Standard; ComponentLink1Description: Datasheet; ComponentLink1URL: https://cop201.prevas.dk/viewvc/datasheet/INNISI-31.pdf?root=hw_components&view=co; db_created: 2015-06-03 10:28:51.0; db_last_updated: 03-06-2015 10:34:21; db_local_id: INNISI-31; db_status: Prototype; elec_value: WiFiHU-c; ref_datasheet: INNISI-31; source_manufacturer_1_pn: WiFiHU-c; Library Name: altium_mysql.dblib
X3 = NIMA-67: 24MHz 18pF ±50ppm Crystal; ref_part_type: /oscillator/crystal/; source_manufacturer_1: Hosonic; source_manufacturer_1_pn: E7SB24.0000F18M55; cae_altium_pcb_status: Prototype; Database Table Name: altium_default; db_rev: 1265; elec_power_rating: 100µW; elec_temp_range: -40 to 85; phy_height: 1.1mm; phy_mounting: SMD; phy_packaging_type: 7x5mm; ref_datasheet: NIMA-52; ref_keyword: 12SMX_B; cae_altium_sch_status: Prototype; Component Kind: Standard; ComponentLink1Description: Datasheet; ComponentLink1URL: https://cop201.prevas.dk/viewvc/datasheet/NIMA-52.pdf?root=hw_components&view=co; db_created: 2009-04-28 19:20:34; db_last_updated: 28-04-2009 19:20:34; db_local_id: NIMA-67; db_status: Prototype; elec_frequency_rating: 24.0MHz; elec_tolerance: ±50ppm; elec_value: 24.0MHz; Mounted: Yes; Library Name: altium_mysql.dblib
